# Altium schematic sheet Tartarus Joystick Mod M0.SchDoc("Tartarus Joystick Mod M0")
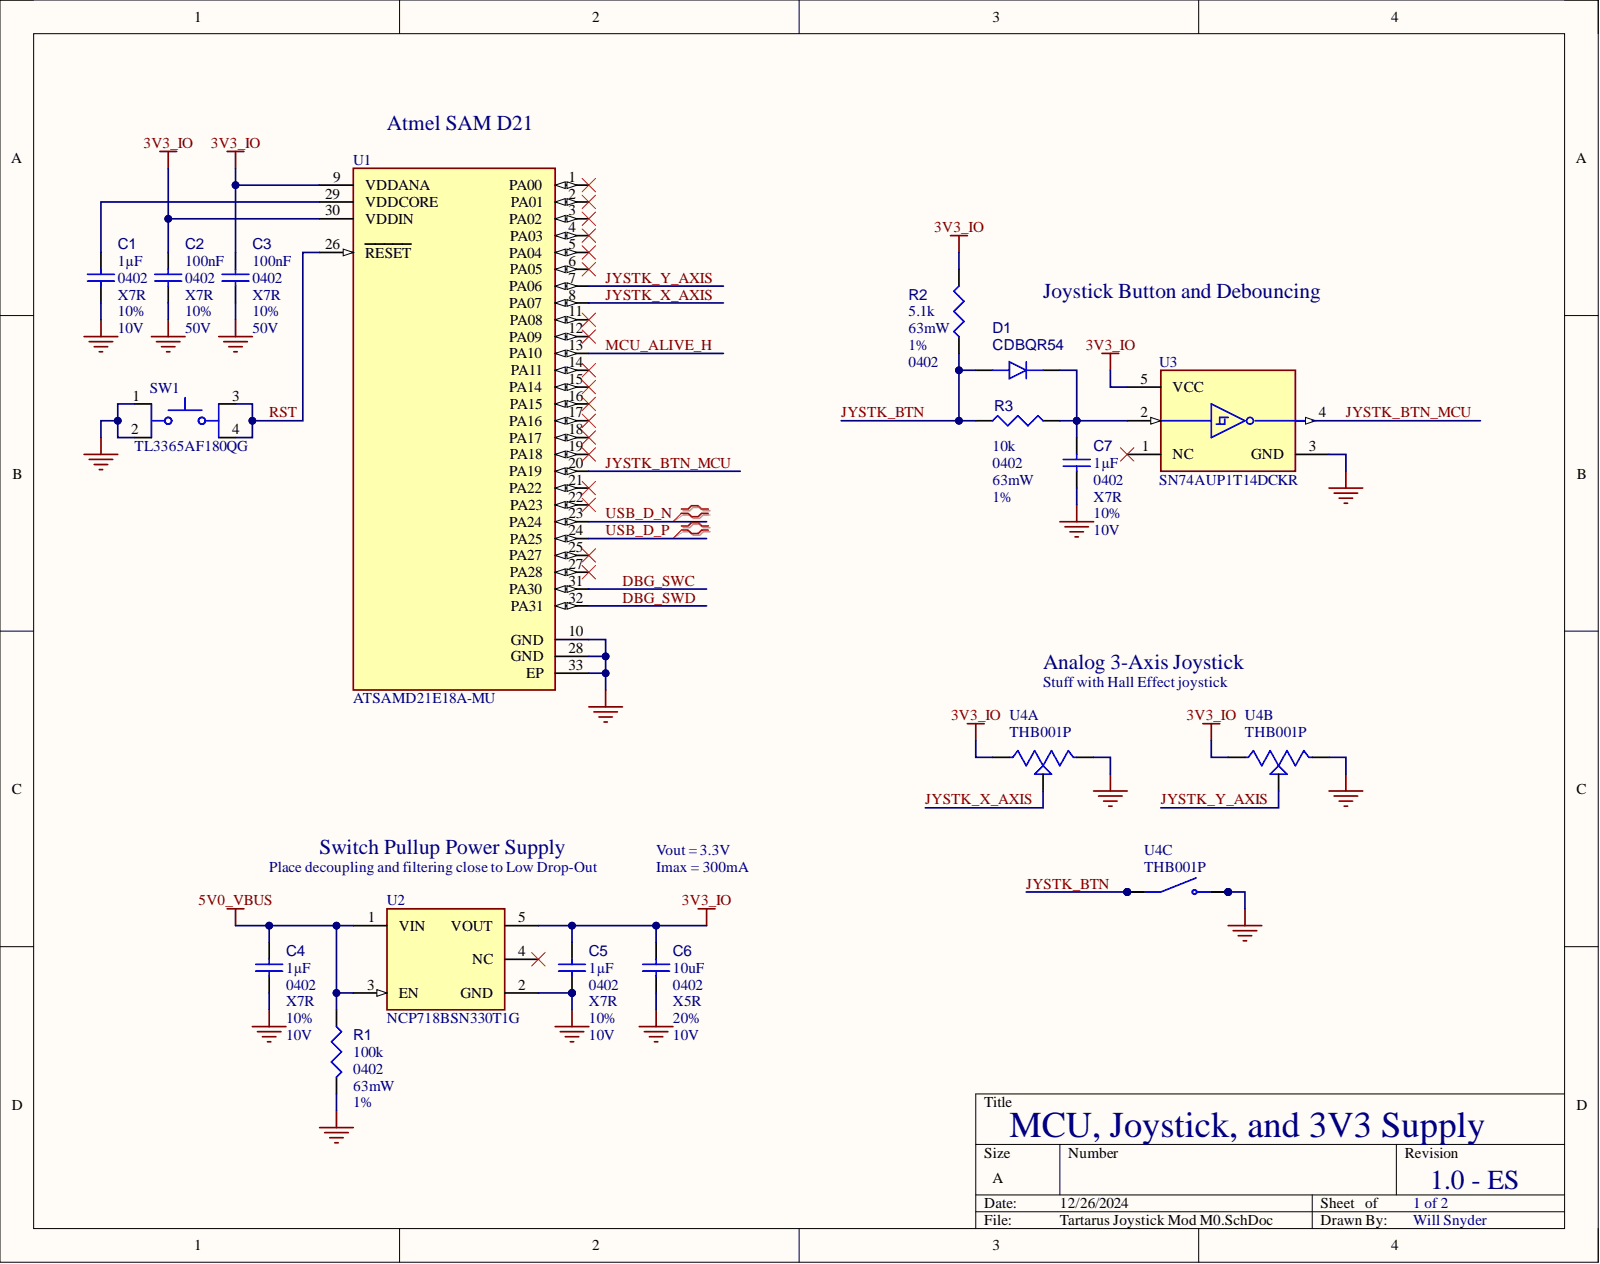
[diagram p0: Tartarus Joystick Mod M0.SchDoc]

## Parts
C1  value 1µF  Capacitor Type: X7R  Case/Package: 0402  Tolerance: 10%  Voltage Rating: 10V  (hidden comment: GRM155Z71A105KE01D)
C2  value 100nF  Capacitor Type: X7R  Case/Package: 0402  Tolerance: 10%  Voltage Rating: 50V  (hidden comment: 04025C104KAT4A)
C3  value 100nF  Capacitor Type: X7R  Case/Package: 0402  Tolerance: 10%  Voltage Rating: 50V  (hidden comment: 04025C104KAT4A)
C4  value 1µF  Capacitor Type: X7R  Case/Package: 0402  Tolerance: 10%  (hidden comment: GRM155Z71A105KE01D)
C5  value 1µF  Capacitor Type: X7R  Case/Package: 0402  Tolerance: 10%  (hidden comment: GRM155Z71A105KE01D)
C6  value 10uF  Capacitor Type: X5R  Case/Package: 0402  Tolerance: 20%  (hidden comment: GRM155R61A106ME11J)
C7  value 1µF  Capacitor Type: X7R  Case/Package: 0402  Tolerance: 10%  (hidden comment: GRM155Z71A105KE01D)
D1  CDBQR54
R1  value 100k  Case/Package: 0402  Power: 63mW  Tolerance: 1%  (hidden comment: 100KR2F)
R2  value 5.1k  Case/Package: 0402  Power: 63mW  Tolerance: 1%  (hidden comment: MCS04020C5101FE000)
R3  value 10k  (hidden comment: MCS04020C2202FE000)
SW1  TL3365AF180QG
U1  ATSAMD21E18A-MU
U2  NCP718BSN330T1G
U3  SN74AUP1T14DCKR
U4  THB001P  (parts A,B,C)

## Nets
3V3_IO: C2.1 C3.1 C5.1 C6.1 R2.2 U1.9(VDDANA) U1.30(VDDIN) U2.5(VOUT) U3.5(VCC) U4.VR1_1 U4.VR2_1
5V0_VBUS: C4.1 R1.1 U2.1(VIN) U2.3(EN)
GND: C1.2 C2.2 C3.2 C4.2 C5.2 C6.2 C7.2 R1.2 SW1.1 SW1.2 U1.10(GND) U1.28(GND) U1.33(EP) U2.2(GND) U3.3(GND) U4.A U4.B U4.VR1_3 U4.VR2_3
DBG_SWC: U1.31(PA30)
DBG_SWD: U1.32(PA31)
JYSTK_BTN: D1.2 R2.1 R3.1 U4.C U4.D
JYSTK_BTN_MCU: U1.20(PA19) U3.4
JYSTK_X_AXIS: U1.8(PA07) U4.VR1_2
JYSTK_Y_AXIS: U1.7(PA06) U4.VR2_2
MCU_ALIVE_H: U1.13(PA10)
RST: SW1.3 SW1.4 U1.26(RESET)
USB_D_N: U1.23(PA24)
USB_D_P: U1.24(PA25)
NetC1_1: C1.1 U1.29(VDDCORE)
NetC7_1: C7.1 D1.1 R3.2 U3.2

Not connected: U1 1(PA00) 2(PA01) 3(PA02) 4(PA03) 5(PA04) 6(PA05) 11(PA08) 12(PA09) 14(PA11) 15(PA14) 16(PA15) 17(PA16) 18(PA17) 19(PA18) 21(PA22) 22(PA23) 25(PA27) 27(PA28); U2 4(NC); U3 1(NC)

## Library data of the parts
C1 C4 C5 C7 = CMP-001-00092-1: Ceramic Capacitor, Multilayer, Ceramic, 10V, 10% +Tol, 10% -Tol, X7R, 15% TC, 1uF, Surface Mount, 0402; Component Kind: Standard; Library Name: Personal Workspace; Max Operating Temperature: 125°C; Min Operating Temperature: -55°C; Mounting Technology: Surface Mount; Pins: 2; RoHS Compliant: Yes
  C4 C5 C7: Voltage Rating: 10V
C2 C3 = CMP-001-00090-1: Ceramic Capacitor, Multilayer, Ceramic, 50V, 10% +Tol, 10% -Tol, X7R, 15% TC, 0.1uF, Surface Mount, 0402; Component Kind: Standard; Library Name: Personal Workspace; Pins: 2; RoHS Compliant: Yes
C6 = CMP-001-00091-1: Ceramic Capacitor, Multilayer, Ceramic, 10V, 20% +Tol, 20% -Tol, X5R, 15% TC, 10uF, Surface Mount, 0402; Component Kind: Standard; Library Name: Personal Workspace; Voltage Rating: 10V
D1 = CMP-004-00041-1: Rectifier Diode, Schottky, 1 Phase, 1 Element, 0.2A, 30V V(RRM), Silicon; Forward Voltage: 240mV; Component Kind: Standard; Library Name: Personal Workspace; Max Operating Temperature: 125°C; RoHS Compliant: Yes
R1 = CMP-009-00064-2: 100K 0.063W 1% 0402 (1005 Metric)  SMD; Component Kind: Standard; Library Name: Personal Workspace; Mounting Technology: SMT; Pins: 2
R2 = CMP-009-00205-1: Fixed Resistor, Thin Film, 0.063W, 5100ohm, 50V, 1% +/-Tol, 50ppm/Cel, Surface Mount, 0402; Component Kind: Standard; Library Name: Personal Workspace; Max Operating Temperature: 125°C; Min Operating Temperature: -55°C; Mounting Technology: Surface Mount; Pins: 2; RoHS Compliant: Yes
R3 = CMP-009-00206-1: Fixed Resistor, Thin Film, 0.063W, 22000ohm, 50V, 1% +/-Tol, 50ppm/Cel, Surface Mount, 0402; Power: 63mW; Case/Package: 0402; Component Kind: Standard; Library Name: Personal Workspace; Max Operating Temperature: 125°C; Min Operating Temperature: -55°C; Mounting Technology: 0402; Pins: 2; RoHS Compliant: Yes; Tolerance: 1%
SW1 = CMP-011-00019-2: Tactile Switch SPST-NO Top Actuated Surface Mount; MF: E-Switch; Availability: In Stock; Case/Package: None; Check_prices: https://www.snapeda.com/parts/TL3365AF180QG/E-Switch/view-part/?ref=eda; Component Kind: Standard; Component Type: Standard; Design Item ID: TL3365AF180QG; Library Name: Personal Workspace; MP: TL3365AF180QG; Pins: 4; Price: None; Purchase-URL: https://pricing.snapeda.com/search/part/TL3365AF180QG/?ref=eda; SnapEDA_Link: https://www.snapeda.com/parts/TL3365AF180QG/E-Switch/view-part/?ref=snap; Source: TL3365AF180QG.SchLib
U1 = CMP-020-00023-1: RISC Microcontroller, 32-Bit, FLASH, CORTEX-M0 CPU, 48MHz, CMOS; Clock Frequency: 32MHz; Max Supply Voltage: 3.63V; Min Supply Voltage: 1.62V; Nominal Supply Voltage: 3.3V; Case/Package: HVQCCN; Component Kind: Standard; Library Name: Personal Workspace; Max Operating Temperature: 85°C; Min Operating Temperature: -40°C; Pins: 32; RoHS Compliant: Yes
U2 = CMP-019-00058-1: Fixed Positive LDO Regulator, 3.3V, 440V Dropout, PDSO5; Component Kind: Standard; Library Name: Personal Workspace; RoHS Compliant: Yes
U3 = CMP-016-00010-1: Inverter, AUP/ULP/V Series, 1-Func, 1-Input, CMOS, PDSO5; Max Supply Voltage: 3.6V; Min Supply Voltage: 2.3V; Nominal Supply Voltage: 2.5V; Case/Package: TSSOP; Component Kind: Standard; Library Name: Personal Workspace; Logic Type: INVERTER; Max Operating Temperature: 85°C; Min Operating Temperature: -40°C; Pins: 5; RoHS Compliant: Yes
U4 = CMP-062-000000-2: Joystick, 2 - Axis Analog (Resistive) Output; MF: C&K; Availability: In Stock; Check_prices: https://www.snapeda.com/parts/THB001P/C%2526K/view-part/?ref=eda; Component Kind: Standard; Component Type: Standard; Design Item ID: THB001P; Library Name: Personal Workspace; MP: THB001P; Package: None; Pin Count: 10; Price: None; Purchase-URL: https://pricing.snapeda.com/search/part/THB001P/?ref=eda; SnapEDA_Link: https://www.snapeda.com/parts/THB001P/C%2526K/view-part/?ref=snap; Source: THB001P.SchLib
